# Altium schematic sheet SE-HV00-02.SchDoc(SE-HV00-02)
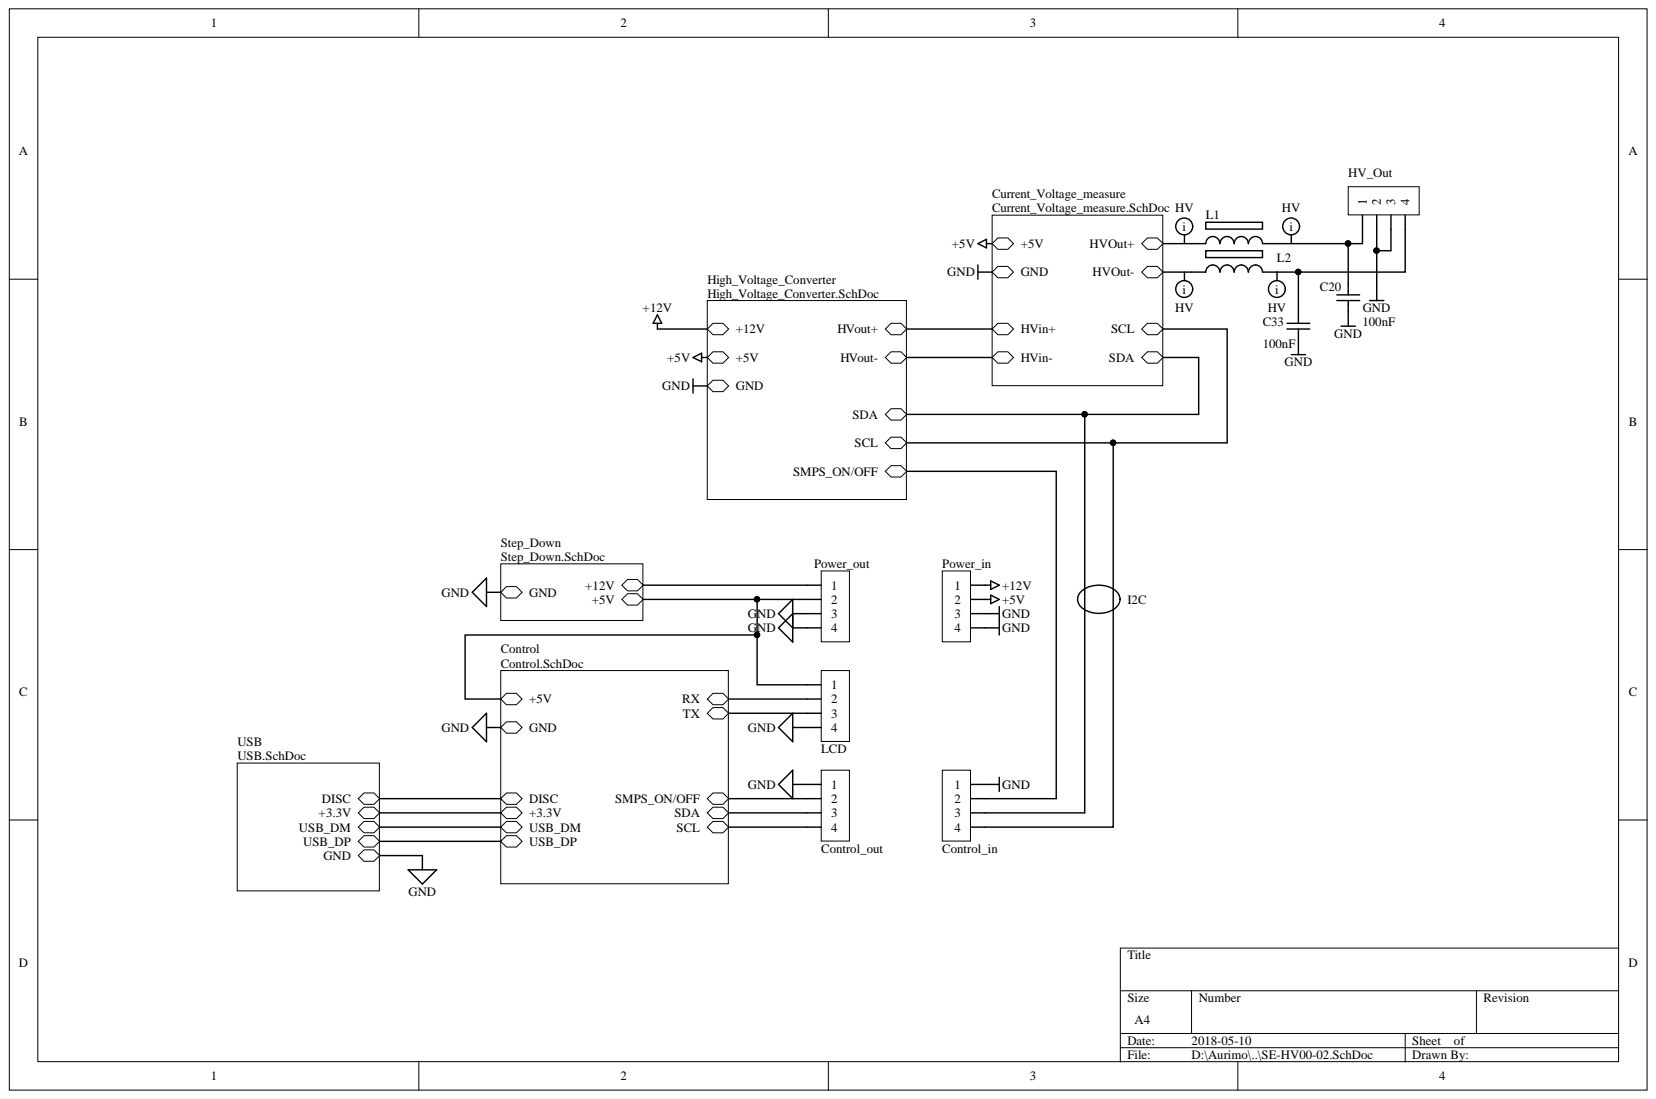
[diagram p0: SE-HV00-02.SchDoc]

## Parts
C20  100nF  [C1808]
C33  100nF  [C1808]
Control_in  4 Pin Socket  [RA Socket 4 pin]  (value not drawn)
Control_out  HDR 4 Pin  [RA HDR 4Pin]  (value not drawn)
HV_Out  HDR 4  [HDR1X4_3M96V]  (value not drawn)
L1  (no value)  [LL0805\2P]
L2  (no value)  [LL0805\2P]
LCD  B4B-XH-A  [B4B-XH-A]  (value not drawn)
Power_in  4 Pin Socket  [RA Socket 4 pin]  (value not drawn)
Power_out  HDR 4 Pin  [RA HDR 4Pin]  (value not drawn)

## Nets
+5V: Power_in.2
+12V: Power_in.1
GND: C20.1 C33.1 Control_in.1 Control_out.1 HV_Out.2 HV_Out.3 LCD.4 Power_in.3 Power_in.4 Power_out.3 Power_out.4
NetC20_2: C20.2 HV_Out.1 L1.2
NetC33_2: C33.2 HV_Out.4 L2.2
NetControl_in_2: Control_in.2
NetControl_in_3: Control_in.3
NetControl_in_4: Control_in.4
NetControl_out_2: Control_out.2
NetControl_out_3: Control_out.3
NetControl_out_4: Control_out.4
NetL1_1: L1.1
NetL2_1: L2.1
NetLCD_1: LCD.1 Power_out.2
NetLCD_2: LCD.2
NetLCD_3: LCD.3
NetPower_out_1: Power_out.1
+3.3V:
DISC:
HVin+:
HVin-:
USB_DM:
USB_DP:

## Library data of the parts
C20 C33 = C0000111: MLCC, X7R, 100NF, 630V, 1808; Manufacturer Part Number: C1808V104KBRACTU; Manufacturer: KEMET; Voltage: 630; Tolerance: ± 10%; Database Table Name: Capacitors; Supplier Part Number 2: 2085209; Supplier 2: Mouser; Supplier 1: Farnell; SMD: Yes; Part Type: MLCC; Part Number: C0000111; Package: C1808; Material: X7R; ComponentLink1Description: MFG link; Component Kind: Standard; Library Name: KTU_DB.DbLib
Control_in Power_in = Right angle socket conn 2.54, single row: Right angle socket conn 2.54mm single row; Component Kind: Standard; Library Name: D_klase.IntLib
Control_out Power_out = Right angle haeder 2.54 mm single row: Description: Right angle haeder 2.54 mm single row; Component Kind: Standard; Library Name: D_klase.IntLib
HV_Out = J0000151: CONNECTOR, 4 way , HEADER, THT, 3.96MM,10A,250Vac; Manufacturer Part Number: B4P-VH(LF)(SN); Manufacturer: JST; Project: RALIS; Note: S; Gender: Male; Database Table Name: Connectors; Supplier Part Number 2: 455-1641-ND; Supplier Part Number 1: 9492020; Supplier 2: Digi-Key; Supplier 1: Farnell; SMD: No; Pitch: 3.96MM; Part Type: Connector\Wire to Board; Part Number: J0000151; Data: 2014-12-15; ComponentLink1URL: http://www.jst-mfg.com/product/detail_e.php?series=262; ComponentLink1Description: MFG link; Component Kind: Standard; Aproved: -; Library Name: KTU_DB.DbLib
L1 L2 = L0000002: BEAD SMD EMI FERRITE 0805 220 OHM; Manufacturer Part Number: BLM21PG221SN1D; Manufacturer: Murata; Resistance: 220 OHM; Database Table Name: Inductors; SMD: Yes; Part Type: Ferrite\Bead; Part Number: L0000002; Data: 2011-01-26; ComponentLink2Description: DataSheet; ComponentLink1Description: MFG link; Component Kind: Standard; Library Name: KTU_DB.DbLib
LCD = J0000086: Wire to Board Header, THT, 2.5MM, 4WAY; Manufacturer Part Number: B4B-XH-A (LF)(SN); Manufacturer: JST; Note: S; Gender: Pin (Male); Database Table Name: Connectors; Supplier Part Number 2: 455-2249-ND; Supplier Part Number 1: 1516278; Supplier 2: Digikey; Supplier 1: Farnell; SMD: No; Pitch: 2.5mm; Part Type: Connector\Wire to Board; Part Number: J0000086; Orientation: Straight PCB PTH; Data: 2012-06-06; ComponentLink1URL: http://www.farnell.com/datasheets/5448.pdf; ComponentLink1Description: MFG link; Component Kind: Standard; Aproved: -; Library Name: KTU_DB.DbLib
